# Altium schematic sheet Sheet1.SchDoc
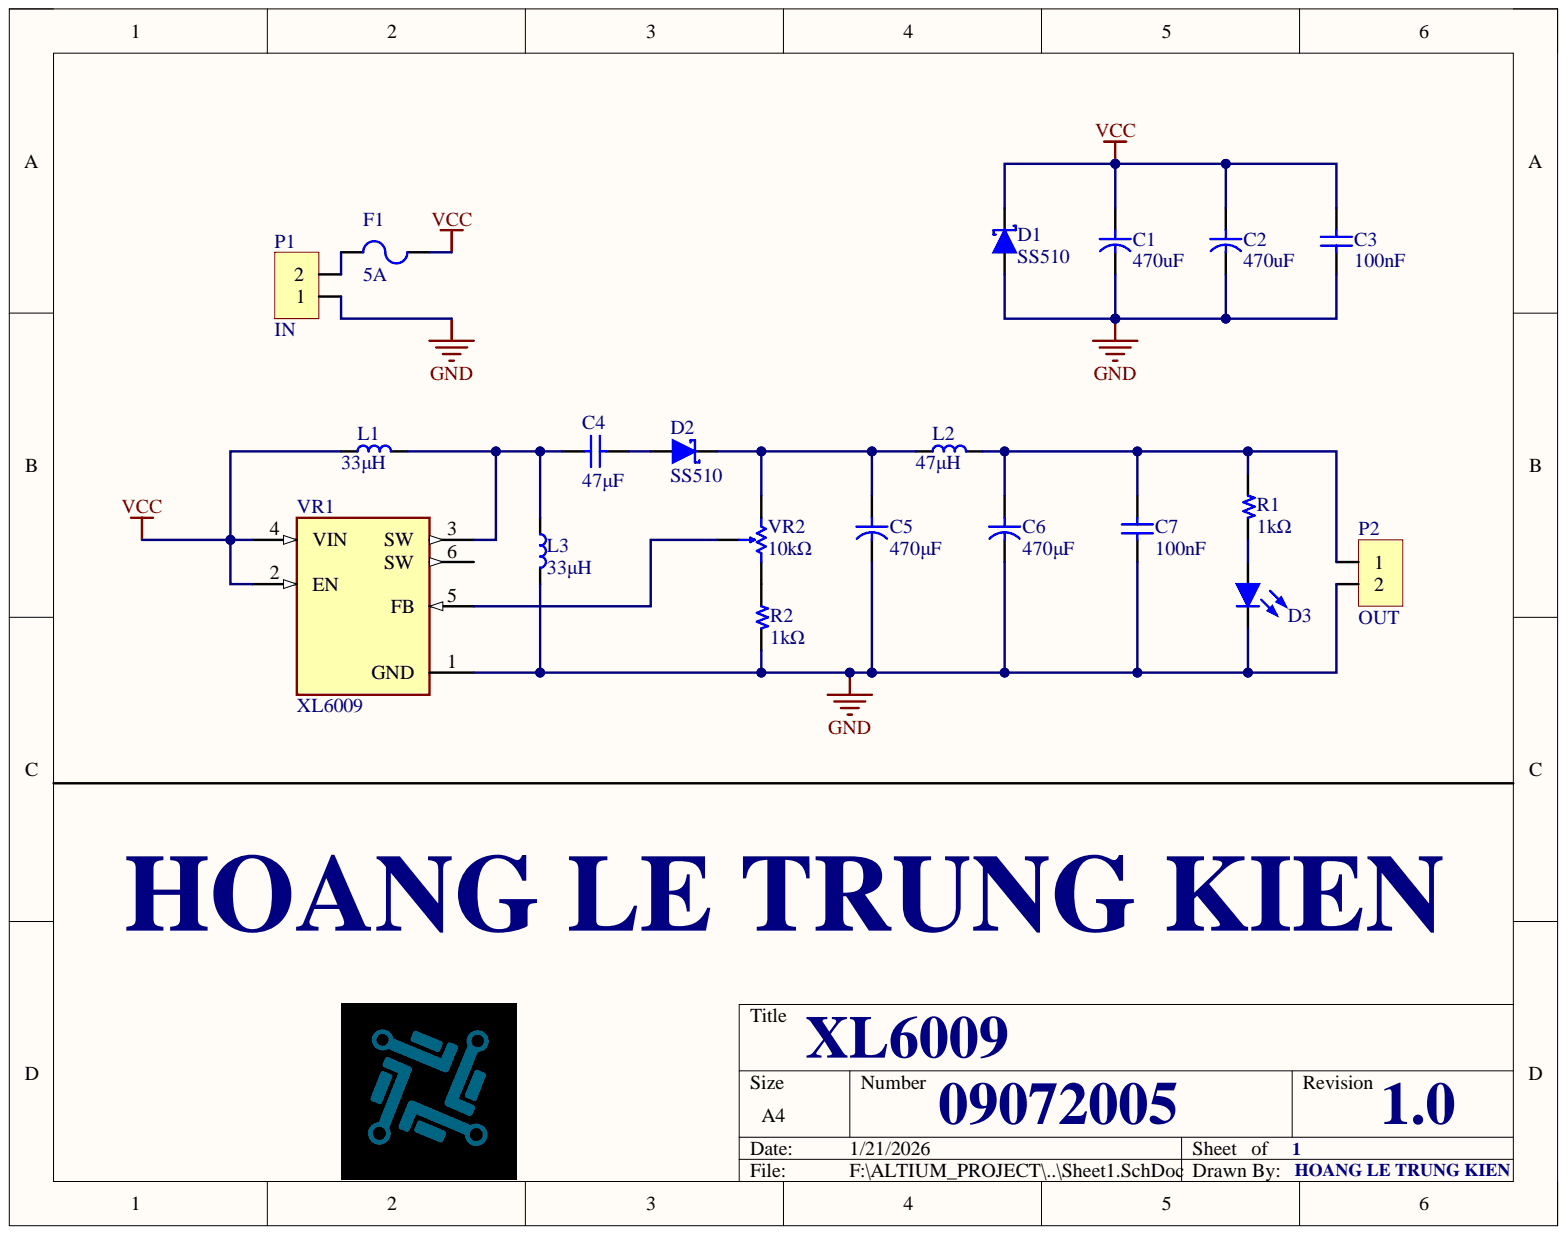
[diagram p0: Sheet1.SchDoc]

## Parts
C1  470uF  [CYL/D.400/LS.200]
C2  470uF  [CYL/D.400/LS.200]
C3  100nF  [C 1206]
C4  47μF  [CAP Ceramic/W300]
C5  470μF  [CYL/D.400/LS.200]
C6  470μF  [CYL/D.400/LS.200]
C7  100nF  [C 1206]
D1  SS510  [DO-214AB (SMC)]
D2  SS510  [DO-214AB (SMC)]
D3  Led  [Led 0805 RED]  (value not drawn)
F1  5A  [Fuse 1812P050]
L1  33μH  [Inductor SMD 12x12x8]
L2  47μH  [Inductor SMD 12x12x8]
L3  33μH  [Inductor SMD 12x12x8]
P1  IN  [HDR/Terminal/2/5mm]
P2  OUT  [HDR/Terminal/2/5mm]
R1  1kΩ  [R 1206]
R2  1kΩ  [R 1206]
VR1  XL6009  [DPAK170P1435X465-6N]
VR2  10kΩ  [R VAR E]

## Nets
GND: C1.2 C2.2 C3.2 C5.2 C6.2 C7.1 D1.1 D3.2 L3.2 P1.1 P2.2 R2.2 VR1.1(GND)
VCC: C1.1 C2.1 C3.1 D1.2 F1.1 L1.1 VR1.2(EN) VR1.4(VIN)
NetC4_1: C4.1 L1.2 L3.1 VR1.3(SW)
NetC4_2: C4.2 D2.1
NetC5_1: C5.1 D2.2 L2.1 VR2.3
NetC6_1: C6.1 C7.2 L2.2 P2.1 R1.1
NetD3_1: D3.1 R1.2
NetF1_2: F1.2 P1.2
NetR2_1: R2.1 VR2.1
NetVR1_5: VR1.5(FB) VR2.2

Not connected: VR1 6(SW)

## Library data of the parts
C1 C2 C5 C6 = Capacitor: Capacitor pol; Component Kind: Standard; Library Name: chipfc_altium_libs.IntLib
C3 C4 C7 = Capacitor NP: Capacitor non-pol; Component Kind: Standard; Library Name: chipfc_altium_libs.IntLib
D1 D2 = Diode Schottky: Schottky Diode; Component Kind: Standard; Library Name: chipfc_altium_libs.IntLib
D3 = Led: Typical LED; Component Kind: Standard; Library Name: chipfc_altium_libs.IntLib
F1 = Fuse: Component Kind: Standard; Description: Fuse; Library Name: chipfc_altium_libs.IntLib
L1-L3 = Inductor: Component Kind: Standard; Description: Inductor; Library Name: chipfc_altium_libs.IntLib
P1 P2 = Header 2: Header, 2-Pin; Component Kind: Standard; Library Name: chipfc_altium_libs.IntLib
R1 R2 = Resistor: Component Kind: Standard; Description: Resistor; Library Name: chipfc_altium_libs.IntLib
VR1 = XL6009: The XL6009 regulator is a wide input range, current mode, DC/DC converter which is capable of generating either positive or negative output voltages.; MF: XLSEMI; Availability: Not in stock; Check_prices: https://www.snapeda.com/parts/XL6009/XLSEMI/view-part/?ref=eda; Component Kind: Standard; Library Name: XL6009.IntLib; MP: XL6009; Package: TO-263-5L XLSEMI; Price: None; SnapEDA_Link: https://www.snapeda.com/parts/XL6009/XLSEMI/view-part/?ref=snap
VR2 = Resistor Variable: Component Kind: Standard; Description: Resistor Variable; Library Name: chipfc_altium_libs.IntLib
